# Altium schematic sheet LR1110_e595v03a_Sensors.SchDoc(LR1110_e595v03a_Sensors)
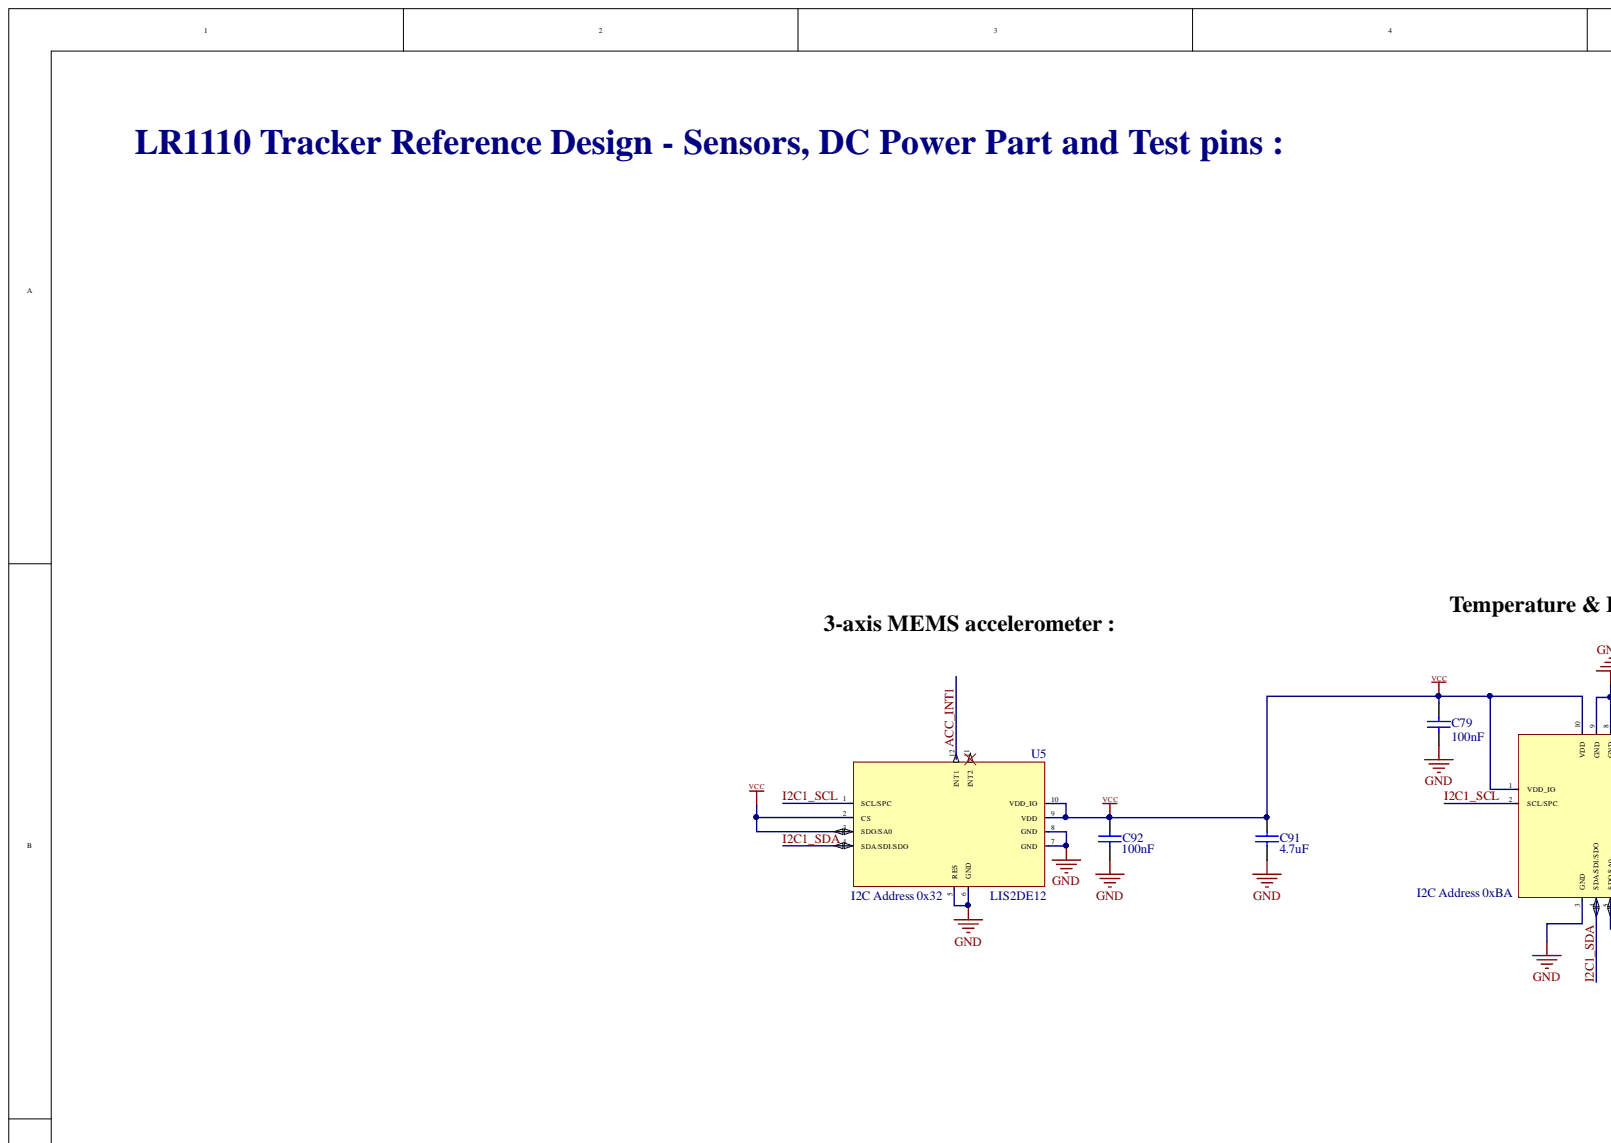
[diagram p0: LR1110_e595v03a_Sensors.SchDoc, top left]
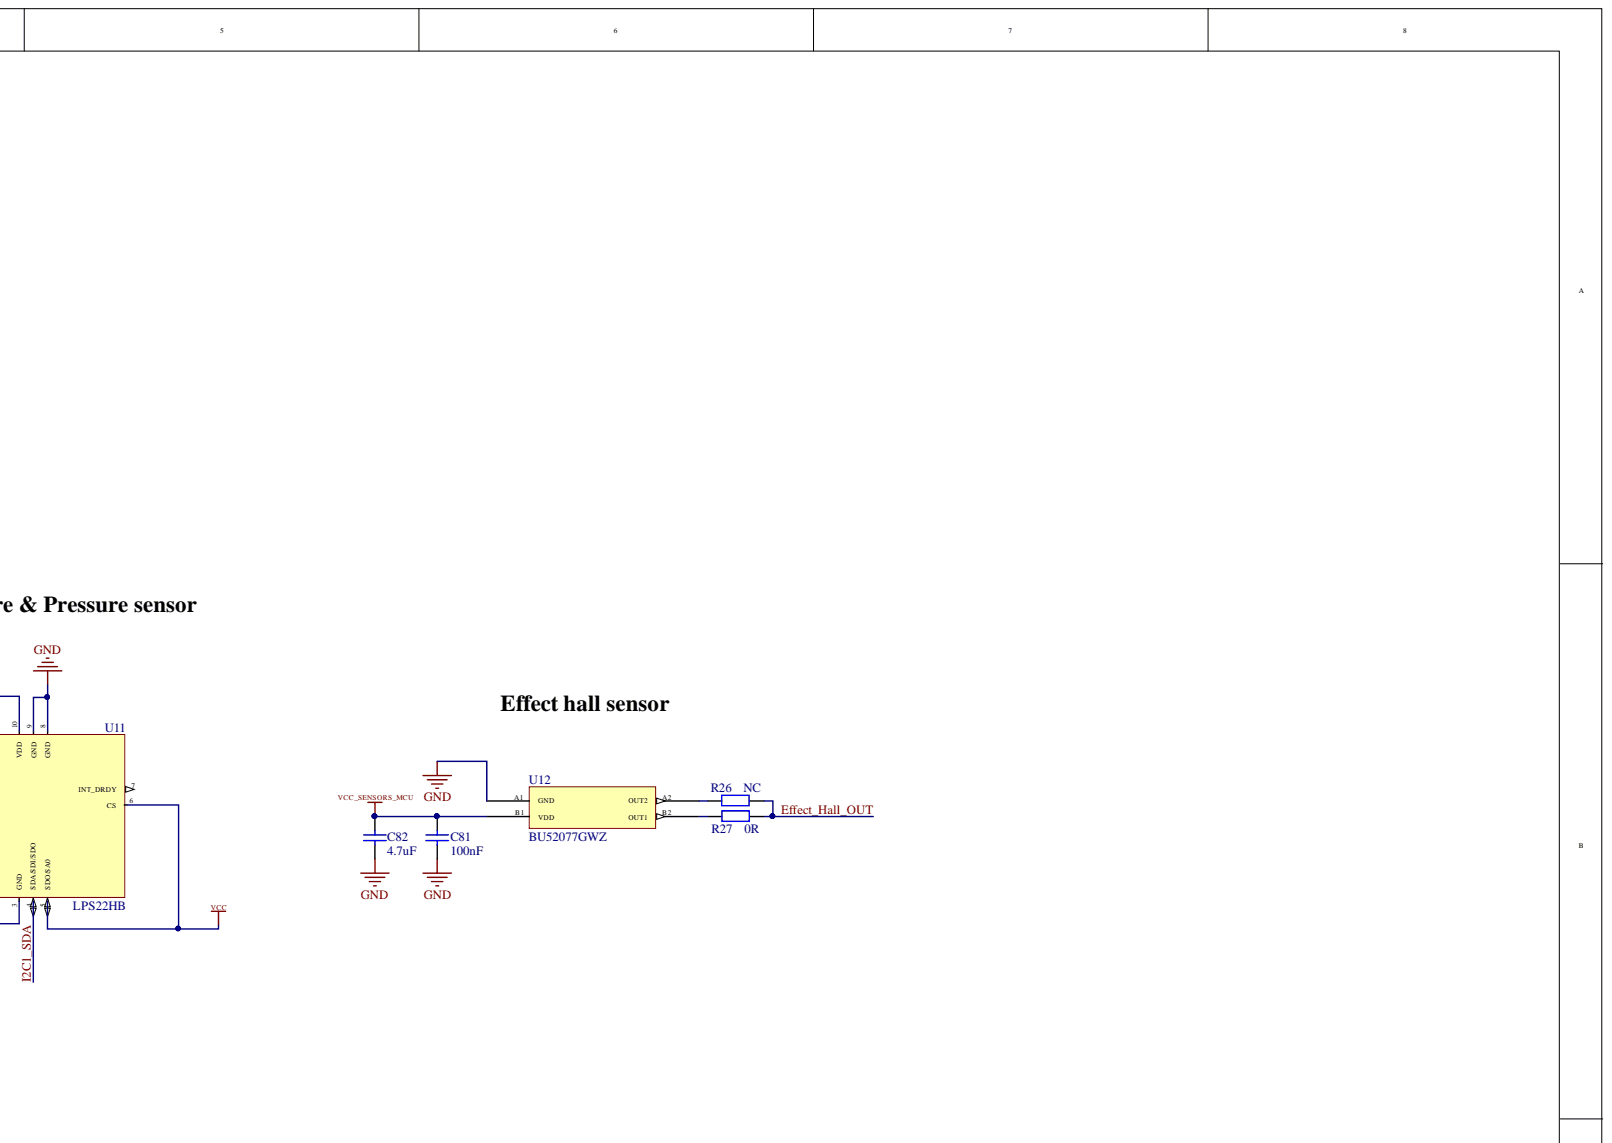
[diagram p1: LR1110_e595v03a_Sensors.SchDoc, top right]
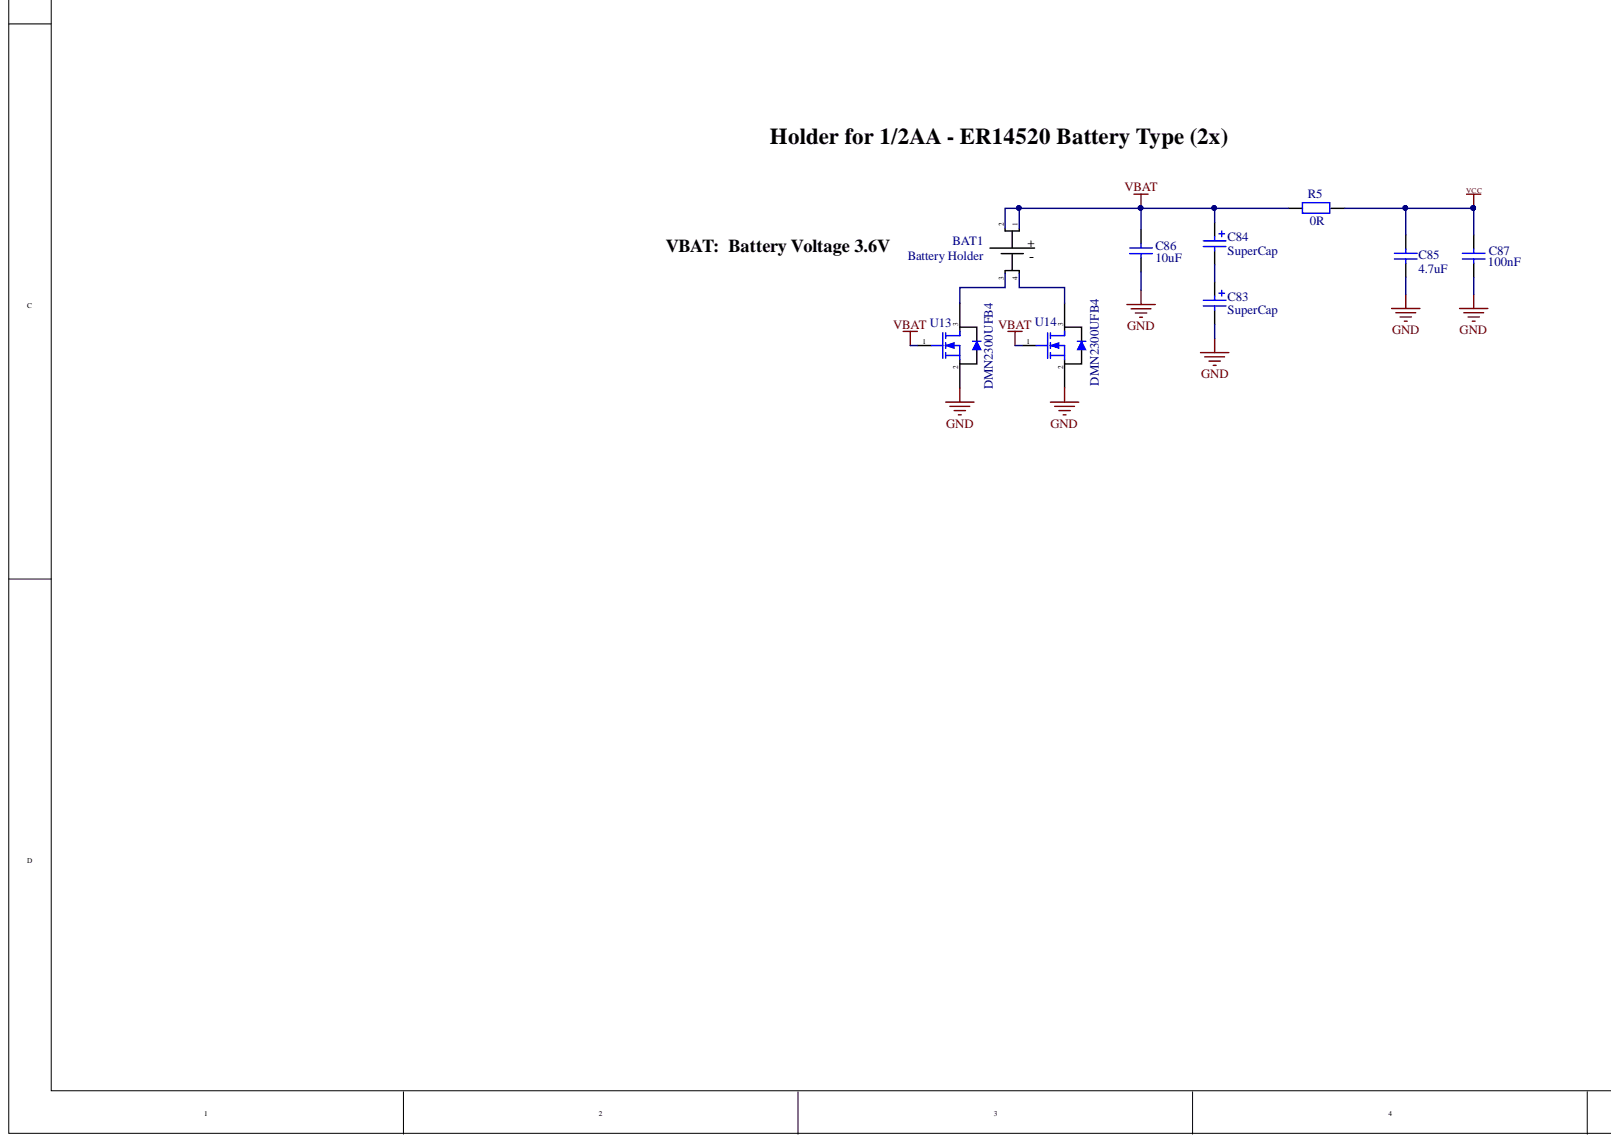
[diagram p2: LR1110_e595v03a_Sensors.SchDoc, bottom left]
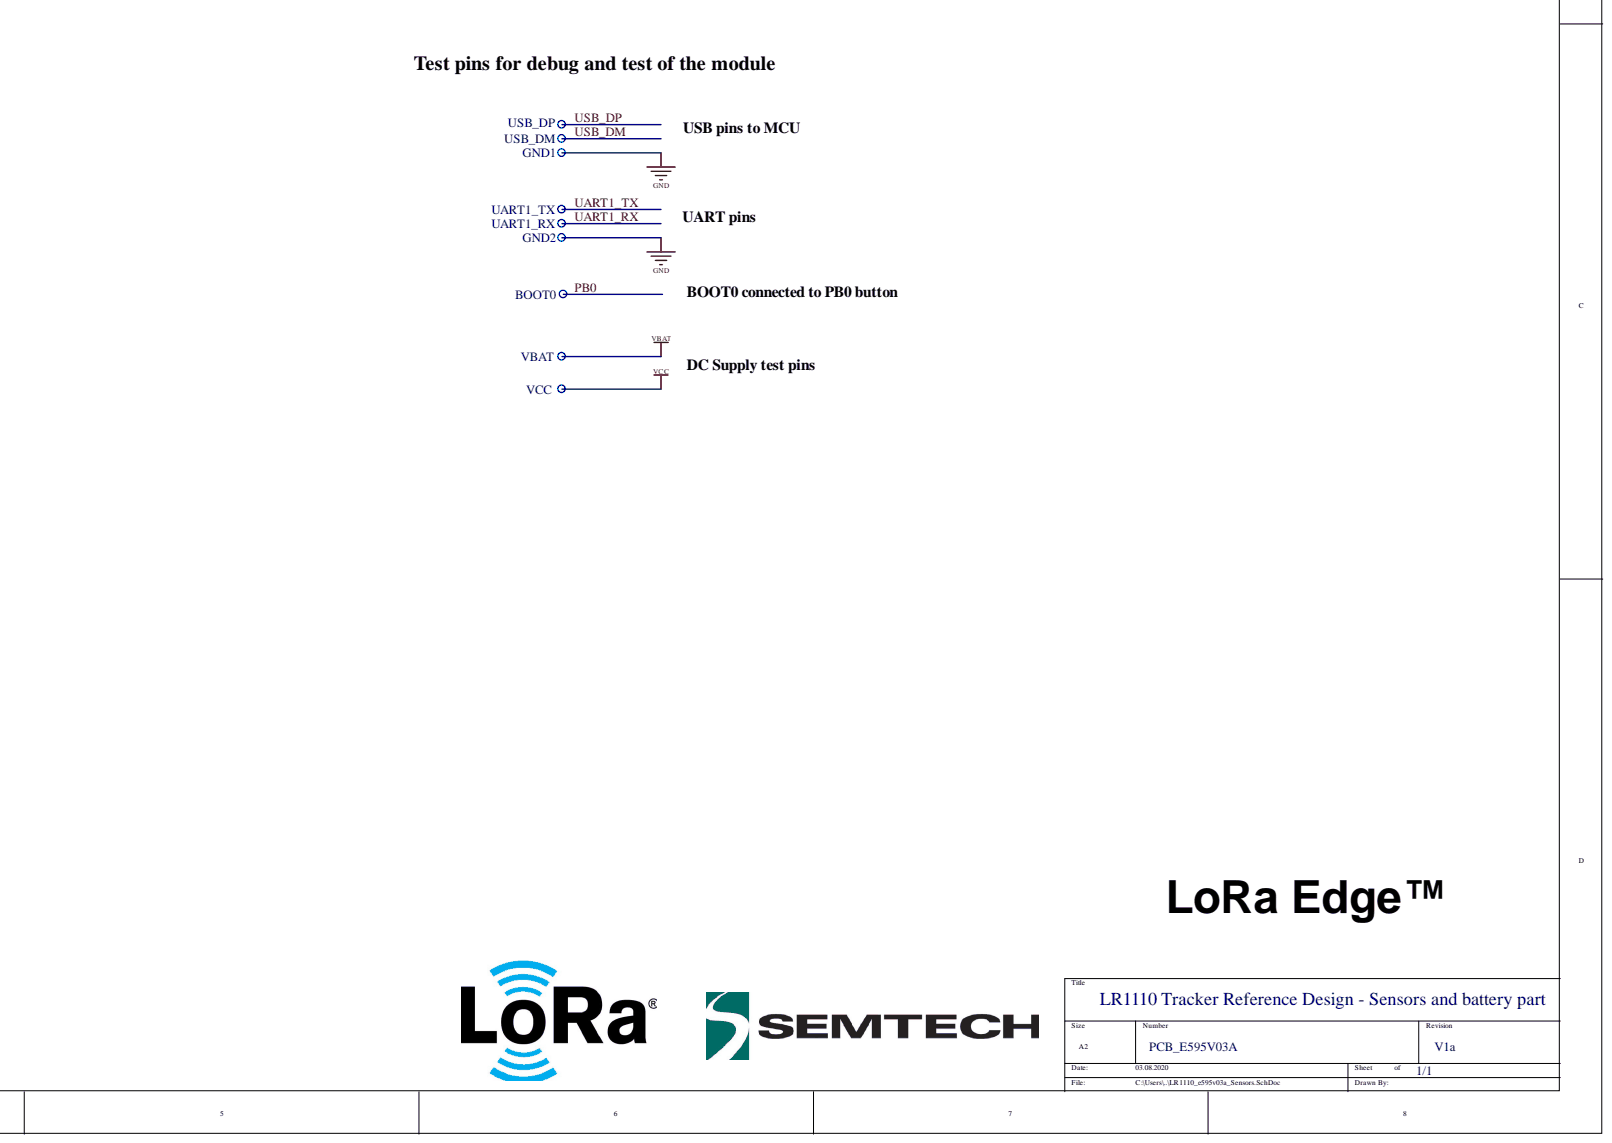
[diagram p3: LR1110_e595v03a_Sensors.SchDoc, bottom right]

## Parts
BAT1  Battery Holder  [BATT_HOLDER_1/2_AA]
BOOT0  Test Pin  [PIN_SMD]  (value not drawn)
C79  value 100nF  [rlc_201_smd_small]  (hidden comment: Cap)
C81  value 100nF  [rlc_201_smd_small]  (hidden comment: Cap)
C82  value 4.7uF  [rlc_402_smd_small]  (hidden comment: Cap)
C83  SuperCap  [CAPPR3.5-8x13]
C84  SuperCap  [CAPPR3.5-8x13]
C85  value 4.7uF  [rlc_603_smd_small]  (hidden comment: Cap)
C86  value 10uF  [rlc_603_smd_small]  (hidden comment: Cap)
C87  value 100nF  [rlc_201_smd_small]  (hidden comment: Cap)
C91  value 4.7uF  [rlc_402_smd_small]  (hidden comment: Cap)
C92  value 100nF  [rlc_201_smd_small]  (hidden comment: Cap)
GND1  Test Pin  [PIN_SMD]  (value not drawn)
GND2  Test Pin  [PIN_SMD]  (value not drawn)
R5  value 0R  [rlc_402_smd_small]  (hidden comment: Resistor)
R26  value NC  [rlc_201_smd_small]  (hidden comment: Resistor)
R27  value 0R  [rlc_201_smd_small]  (hidden comment: Resistor)
U5  LIS2DE12  [LGA-12 2x2mm]
U11  LPS22HB  [HLGA-10L 2x2mm]
U12  BU52077GWZ  [UCSP35L1]
U13  DMN2300UFB4  [X2-DFN1006-3]
U14  DMN2300UFB4  [X2-DFN1006-3]
UART1_RX  Test Pin  [PIN_SMD]  (value not drawn)
UART1_TX  Test Pin  [PIN_SMD]  (value not drawn)
USB_DM  Test Pin  [PIN_SMD]  (value not drawn)
USB_DP  Test Pin  [PIN_SMD]  (value not drawn)
VBAT  Test Pin  [PIN_SMD]  (value not drawn)
VCC  Test Pin  [PIN_SMD]  (value not drawn)

## Nets
GND: C79.1 C81.1 C82.1 C83.2 C85.2 C86.1 C87.1 C91.1 C92.1 GND1.1 GND2.1 U5.5 U5.6 U5.7(GND) U5.8 U11.3(GND) U11.8(GND) U11.9(GND) U12.A1(GND) U13.2 U14.2
VBAT: BAT1.1 BAT1.2 C84.1 C86.2 R5.2 U13.1 U14.1 VBAT.1
VCC: C79.2 C85.1 C87.2 C91.2 C92.2 R5.1 U5.2(CS) U5.3 U5.9(VDD) U5.10 U11.1 U11.5(SDO/SA0) U11.6 U11.10(VDD) VCC.1
VCC_SENSORS_MCU: C81.2 C82.2 U12.B1(VDD)
ACC_INT1: U5.12
Effect_Hall_OUT: R26.1 R27.1
I2C1_SCL: U5.1(SCL/SPC) U11.2
I2C1_SDA: U5.4(SDA/SDI/SDO) U11.4(SDA/SDI/SDO)
PB0: BOOT0.1
UART1_RX: UART1_RX.1
UART1_TX: UART1_TX.1
USB_DM: USB_DM.1
USB_DP: USB_DP.1
NetBAT1_3: BAT1.3 U13.3
NetBAT1_4: BAT1.4 U14.3
NetC83_1: C83.1 C84.2
NetR26_2: R26.2 U12.A2(OUT2)
NetR27_2: R27.2 U12.B2(OUT1)

Not connected: U5 11(IN_T2); U11 7

## Library data of the parts
BAT1 = Battery Holder: Battery Holder for 1/2 AA - non standard; LastRevision: 27-Jul-09  -  Semtech; Library Name: LR1110_e595v03a.SchLib; Component Kind: Standard
BOOT0 GND1 GND2 UART1_RX UART1_TX USB_DM USB_DP VBAT VCC = test_pin: Library Name: LR1110_e595v03a.SchLib; LatestRevisionDate: 05-oct-2007  -  Semtech; Description: Test Pin; Component Kind: Standard
C79 C81 C82 C85-C87 C91 C92 = Cap: Capacitor SMD; Simulation: CAP; Signal Integrity: Cap Semi; Published: 8-Jun-2000; Library Name: LR1110_e595v03a.SchLib; LatestRevisionNote: Re-released for DXP Platform.; LatestRevisionDate: 05-oct-2007  -  Semtech; Component Kind: Standard; Publisher: Altium Limited
C83 C84 = SuperCap: SuperCapacitor; Value: VAL?; Published: 8-Jun-2000; Library Name: LR1110_e595v03a.SchLib; LatestRevisionNote: Re-released for DXP Platform.; LatestRevisionDate: 05-oct-2007  -  Semtech; Component Kind: Standard; Publisher: Altium Limited
R5 R26 R27 = Resistor: Resistor SMD - IEC symbol version; Library Name: LR1110_e595v03a.SchLib; LatestRevisionDate: 27-Jul-20  -  Semtech; Component Kind: Standard
U5 = LIS2DE12: ultra-low-power high-performance 3-axis "femto" accelerometer; Library Name: LR1110_e595v03a.SchLib; ComponentLink1URL: https://www.st.com/resource/en/datasheet/lis2de12.pdf; ComponentLink1Description: DataSheet; Component Kind: Standard
U11 = LPS22HB: MEMS nano pressure sensor: 260-1260 hPa absolute digital output barometer; Library Name: LR1110_e595v03a.SchLib; ComponentLink1URL: https://www.st.com/resource/en/datasheet/dm00140895.pdf; ComponentLink1Description: DataSheet; Component Kind: Standard
U12 = BU52077GWZ: Omnipolar Detection Hall IC; Library Name: LR1110_e595v03a.SchLib; Component Kind: Standard
U13 U14 = DMN2300UFB4: N-Channel MOSFET; Library Name: LR1110_e595v03a.SchLib; LatestRevisionDate: 04-04-14  -  Semtech; Component Kind: Standard
